# Altium schematic sheet GR_Action.SchDoc
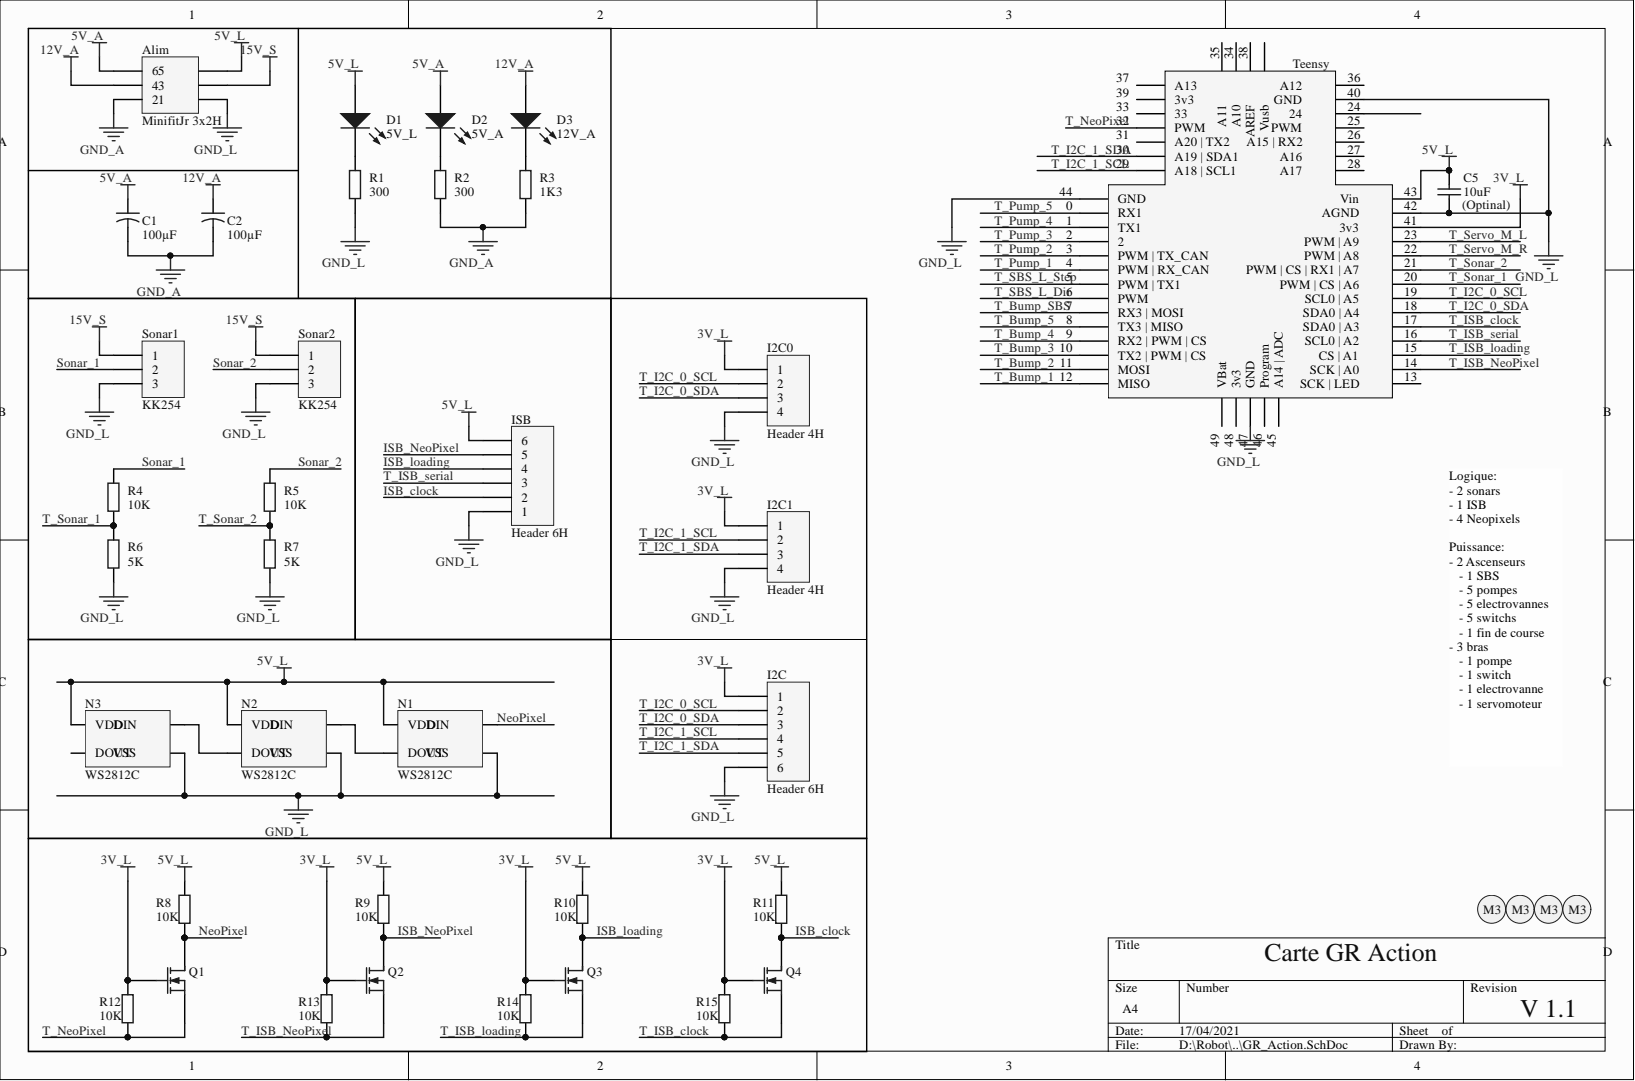
[diagram p0: GR_Action.SchDoc]

## Parts
Alim  MinifitJr 3x2H  [MOLEX_MINIFITJR_3X2]
C1  value 100µF  [Condensateur]  (hidden comment: Cap2)
C2  value 100µF  [Condensateur]  (hidden comment: Cap2)
C5  (Optinal)  value 10uF  [Capacitor 1206]
D1  5V_L  [KP-3216SEC]
D2  5V_A  [KP-3216SEC]
D3  12V_A  [KP-3216SEC]
I2C  Header 6H  [S6B-XH-A]
I2C0  Header 4H  [S4B-XH-A]
I2C1  Header 4H  [S4B-XH-A]
ISB  Header 6H  [S6B-XH-A]
N1  WS2812C  [WS2812C]
N2  WS2812C  [WS2812C]
N3  WS2812C  [WS2812C]
Q1  MOSFET-N  [SOT23_M]  (value not drawn)
Q2  MOSFET-N  [SOT23_M]  (value not drawn)
Q3  MOSFET-N  [SOT23_M]  (value not drawn)
Q4  MOSFET-N  [SOT23_M]  (value not drawn)
R1  value 300  [Resistor 1206]  (hidden comment: Res2)
R2  value 300  [Resistor 1206]  (hidden comment: Res2)
R3  value 1K3  [Resistor 1206]  (hidden comment: Res2)
R4  value 10K  [Resistor 1206]  (hidden comment: Res2)
R5  value 10K  [Resistor 1206]  (hidden comment: Res2)
R6  value 5K  [Resistor 1206]  (hidden comment: Res2)
R7  value 5K  [Resistor 1206]  (hidden comment: Res2)
R8  value 10K  [Resistor 1206]  (hidden comment: Res2)
R9  value 10K  [Resistor 1206]  (hidden comment: Res2)
R10  value 10K  [Resistor 1206]  (hidden comment: Res2)
R11  value 10K  [Resistor 1206]  (hidden comment: Res2)
R12  value 10K  [Resistor 1206]  (hidden comment: Res2)
R13  value 10K  [Resistor 1206]  (hidden comment: Res2)
R14  value 10K  [Resistor 1206]  (hidden comment: Res2)
R15  value 10K  [Resistor 1206]  (hidden comment: Res2)
S1  Screw M3  [Screw M3]  (value not drawn)
S2  Screw M3  [Screw M3]  (value not drawn)
S3  Screw M3  [Screw M3]  (value not drawn)
S4  Screw M3  [Screw M3]  (value not drawn)
Sonar1  KK254  [MOLEX KK254 3X1H M]
Sonar2  KK254  [MOLEX KK254 3X1H M]
Teensy  Teensy 3.2  [teensy]  (value not drawn)

## Nets
3V_L: I2C.1 I2C0.1 I2C1.1 Q1.2 Q2.2 Q3.2 Q4.2 R12.2 R13.2 R14.2 R15.2 Teensy.41
5V_A: Alim.6(6_5) C1.1 D2.1
5V_L: Alim.5 C5.2 D1.1 ISB.6 N1.1 N2.1 N3.1 R8.2 R9.2 R10.2 R11.2 Teensy.43
12V_A: Alim.4(4_3) C2.1 D3.1
15V_S: Alim.3 Sonar1.1 Sonar2.1
GND_A: Alim.2(2_1) C1.2 C2.2 R2.2 R3.2
GND_L: Alim.1 C5.1 I2C.6 I2C0.4 I2C1.4 ISB.1 N1.3 N2.3 N3.3 R1.1 R6.2 R7.2 Sonar1.3 Sonar2.3 Teensy.40 Teensy.42 Teensy.44(GND) Teensy.47(GND)
ISB_CLOCK: ISB.2 Q4.1 R11.1
ISB_LOADING: ISB.4 Q3.1 R10.1
ISB_NEOPIXEL: ISB.5 Q2.1 R9.1
NEOPIXEL: N1.4 Q1.1 R8.1
SONAR_1: R4.1 Sonar1.2
SONAR_2: R5.1 Sonar2.2
T_BUMP_1: Teensy.12(MISO)
T_BUMP_2: Teensy.11(MOSI)
T_BUMP_3: Teensy.10(TX2_|_PWM_|_CS)
T_BUMP_4: Teensy.9(RX2_|_PWM_|_CS)
T_BUMP_5: Teensy.8(TX3_|_MISO)
T_BUMP_SBS: Teensy.7(RX3_|_MOSI)
T_I2C_0_SCL: I2C.2 I2C0.2 Teensy.19
T_I2C_0_SDA: I2C.3 I2C0.3 Teensy.18
T_I2C_1_SCL: I2C.4 I2C1.2 Teensy.29(A18_|_SCL1)
T_I2C_1_SDA: I2C.5 I2C1.3 Teensy.30(A19_|_SDA1)
T_ISB_CLOCK: Q4.3 R15.1 Teensy.17
T_ISB_LOADING: Q3.3 R14.1 Teensy.15
T_ISB_NEOPIXEL: Q2.3 R13.1 Teensy.14
T_ISB_SERIAL: ISB.3 Teensy.16
T_NEOPIXEL: Q1.3 R12.1 Teensy.32(PWM)
T_PUMP_1: Teensy.4(PWM_|_RX_CAN)
T_PUMP_2: Teensy.3(PWM_|_TX_CAN)
T_PUMP_3: Teensy.2
T_PUMP_4: Teensy.1(TX1)
T_PUMP_5: Teensy.0(RX1)
T_SBS_L_DIR: Teensy.6(PWM)
T_SBS_L_STEP: Teensy.5(PWM_|_TX1)
T_SERVO_M_L: Teensy.23
T_SERVO_M_R: Teensy.22
T_SONAR_1: R4.2 R6.1 Teensy.20
T_SONAR_2: R5.2 R7.1 Teensy.21
NetD1_2: D1.2 R1.2
NetD2_2: D2.2 R2.1
NetD3_2: D3.2 R3.1
NetN1_2: N1.2(DOUT) N2.4
NetN2_2: N2.2(DOUT) N3.4

Not connected: N3 2(DOUT); Teensy 13 24 25 26 27 28 31(A20_|_TX2) 33 34 35 36 37(A13) 38 39(3v3) 45(A14_|_ADC) 46(Program) 48(3v3) 49(VBat) 50

## Library data of the parts
Alim = Header 3X2H: Header, 3-Pin, Dual row, Right Angle; Component Kind: Standard; LatestRevisionDate: 17-Jul-2002; LatestRevisionNote: Re-released for DXP Platform.; Library Name: Miscellaneous Connectors.IntLib; Signal Integrity: Connector; Publisher: Altium Limited
C1 C2 = Cap2: Capacitor; Component Kind: Standard; Library Name: Miscellaneous Devices.IntLib; PackageDescription: Capacitor; 2 Leads; PackageReference: CAPR5-4X5; Published: 23-Sep-2002; Signal Integrity: Cap; Simulation: CAP; Publisher: Altium Limited
C5 = Cap: Capacitor; Component Kind: Standard; LatestRevisionDate: 17-Jul-2002; LatestRevisionNote: Re-released for DXP Platform.; Library Name: Miscellaneous Devices.IntLib; PackageDescription: Radial Cap, Thru-Hole; 2 Leads; 0.3 in Pin Spacing; PackageReference: RAD-0.3; Published: 8-Jun-2000; Signal Integrity: Cap; Simulation: CAP; Publisher: Altium Limited
D1-D3 = LED2: Typical RED, GREEN, YELLOW, AMBER GaAs LED; Component Kind: Standard; LatestRevisionDate: 17-Jul-2002; LatestRevisionNote: Re-released for DXP Platform.; Library Name: Miscellaneous Devices.IntLib; PackageDescription: SM LED; 2 Flat Leads; PackageReference: 3.2X1.6X1.1; PackageVersion: Feb-2002; Published: 8-Jun-2000; Simulation: LED2; Publisher: Altium Limited
I2C ISB = Header 6H: Header, 6-Pin, Right Angle; Component Kind: Standard; LatestRevisionDate: 17-Jul-2002; LatestRevisionNote: Re-released for DXP Platform.; Library Name: Miscellaneous Connectors.IntLib; Signal Integrity: Connector; Publisher: Altium Limited
I2C0 I2C1 = Header 4H: Header, 4-Pin, Right Angle; Component Kind: Standard; LatestRevisionDate: 17-Jul-2002; LatestRevisionNote: Re-released for DXP Platform.; Library Name: Miscellaneous Connectors.IntLib; Signal Integrity: Connector; Publisher: Altium Limited
N1-N3 = WS2812C: Intelligent control LED; Component Kind: Standard; Library Name: Robot_2020.SchLib
Q1-Q4 = MOSFET-N: N-Channel MOSFET; Component Kind: Standard; LatestRevisionDate: 17-Jul-2002; LatestRevisionNote: Re-released for DXP Platform.; Library Name: Miscellaneous Devices.IntLib; PackageDescription: TO, Flat Index; 3 In-Line, Axial Leads; Body Dia. 4.6mm; Lead Dia. 0.5 mm (max); PackageReference: E3; PackageVersion: 1995/1996; Published: 8-Jun-2000; Simulation: NMOS; Publisher: Altium Limited
R1-R15 = Res2: Resistor; Component Kind: Standard; LatestRevisionDate: 17-Jul-2002; LatestRevisionNote: Re-released for DXP Platform.; Library Name: Miscellaneous Devices.IntLib; PackageDescription: Axial Device, Thru-Hole; 2 Leads; 0.4 in Pin Spacing; PackageReference: AXIAL-0.4; Published: 8-Jun-2000; Signal Integrity: Res; Simulation: RESISTOR; Publisher: Altium Limited
Sonar1 Sonar2 = Header 3H: Header, 3-Pin, Right Angle; Component Kind: Standard; LatestRevisionDate: 17-Jul-2002; LatestRevisionNote: Re-released for DXP Platform.; Library Name: Miscellaneous Connectors.IntLib; Signal Integrity: Connector; Publisher: Altium Limited
Teensy = teensy3.2: Component Kind: Standard; Description: Teensy 3.2; Library Name: Robot_2020.SchLib
S1-S4 = Screw M3: Component Kind: Standard; Library Name: Robot_2020.SchLib
